# Altium schematic sheet Buttons.SchDoc(Buttons)
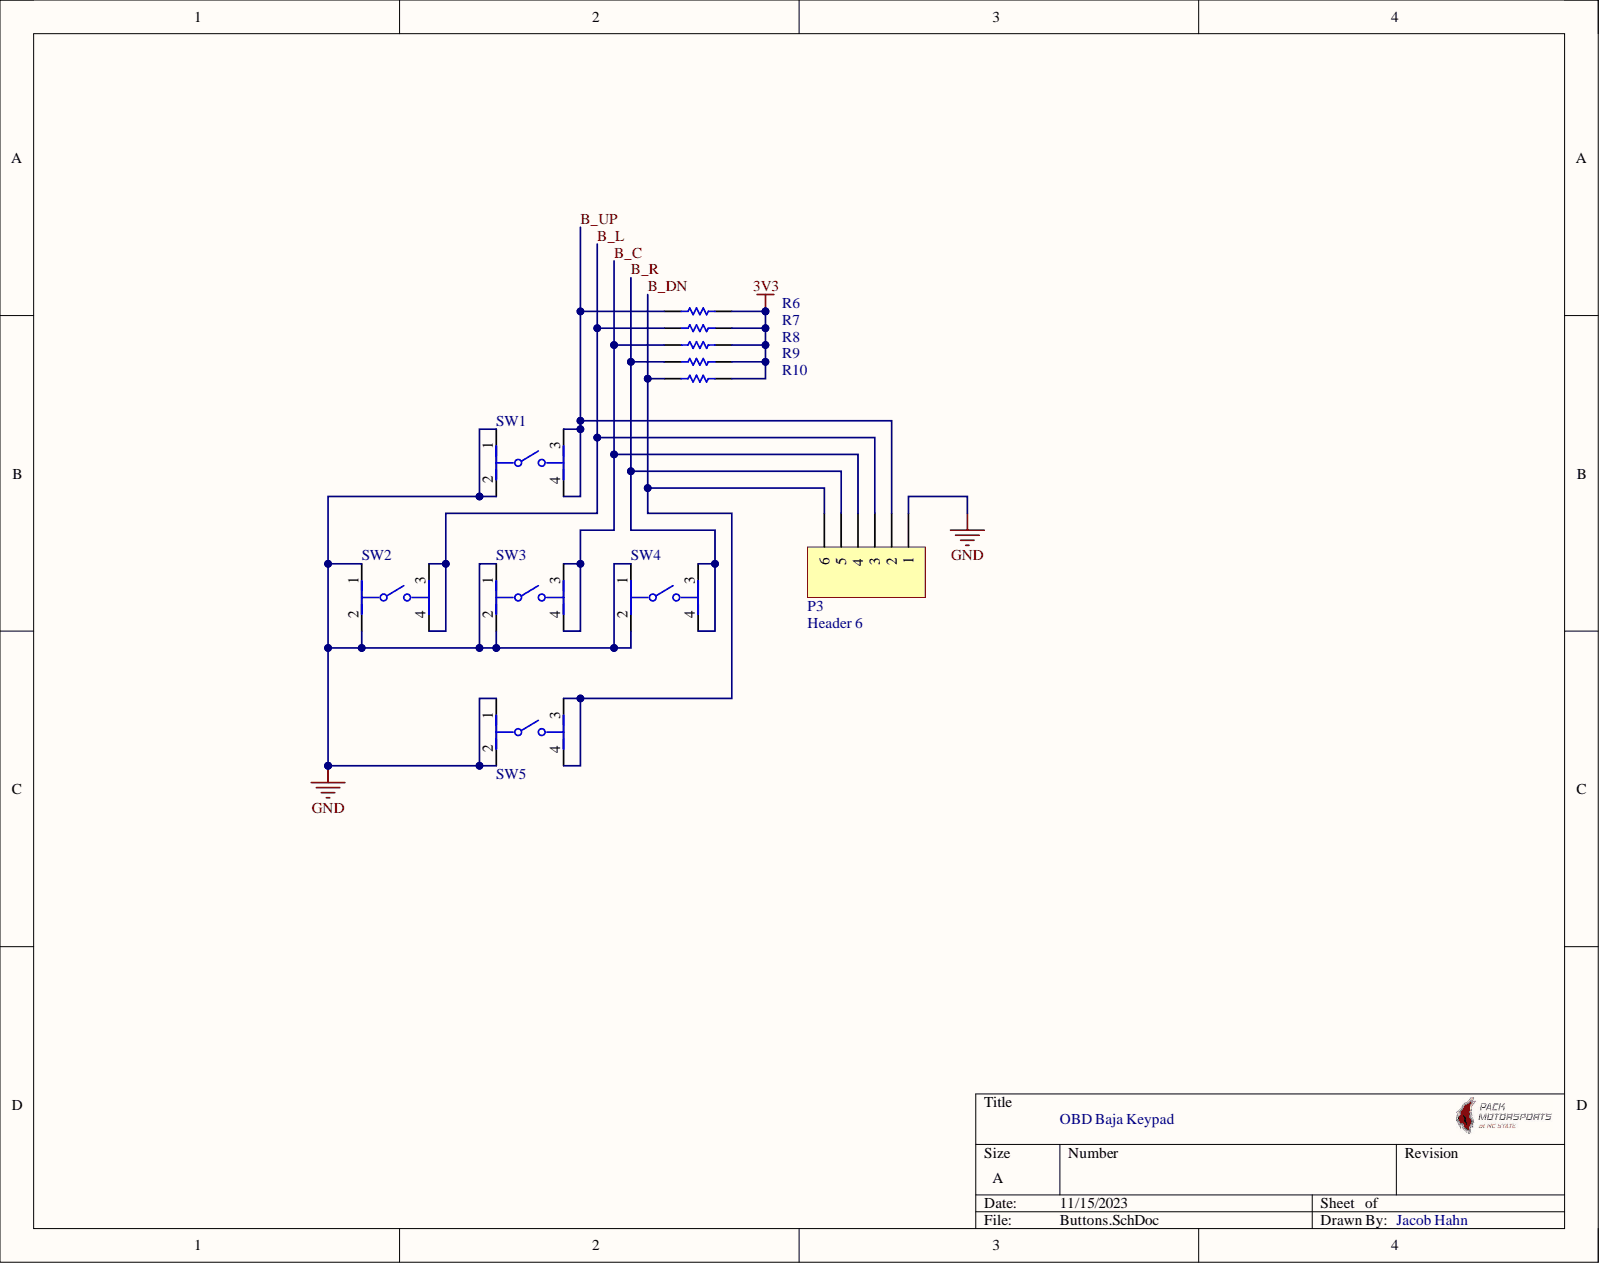
[diagram p0: Buttons.SchDoc]

## Parts
P3  Header 6
R6  RC0805JR-0710KL  (value not drawn)
R7  RC0805JR-0710KL  (value not drawn)
R8  RC0805JR-0710KL  (value not drawn)
R9  RC0805JR-0710KL  (value not drawn)
R10  RC0805JR-0710KL  (value not drawn)
SW1  KMR631NG ULC LFS  (value not drawn)
SW2  KMR631NG ULC LFS  (value not drawn)
SW3  KMR631NG ULC LFS  (value not drawn)
SW4  KMR631NG ULC LFS  (value not drawn)
SW5  KMR631NG ULC LFS  (value not drawn)

## Nets
3V3: R6.2 R7.2 R8.2 R9.2 R10.2
GND: P3.1 SW1.1 SW1.2 SW2.1 SW2.2 SW3.1 SW3.2 SW4.1 SW4.2 SW5.1 SW5.2
B_C: P3.4 R8.1 SW3.3 SW3.4
B_DN: P3.6 R10.1 SW5.3 SW5.4
B_L: P3.3 R7.1 SW2.3 SW2.4
B_R: P3.5 R9.1 SW4.3 SW4.4
B_UP: P3.2 R6.1 SW1.3 SW1.4

## Library data of the parts
P3 = Header 6: Header, 6-Pin; Component Kind: Standard; LatestRevisionDate: 17-Jul-2002; LatestRevisionNote: Re-released for DXP Platform.; Library Name: Miscellaneous Connectors.IntLib; Publisher: Altium Limited
R6-R10 = CMP-2000-05025-1: Chip Resistor, 10 KOhm, +/- 5%, 0.125 W, -55 to 155 degC, 0805 (2012 Metric), RoHS, Tape and Reel; Manufacturer: Yageo; Power Rating: 250mW; Product Range: RCSeries; Resistance: 10kohm; Resistor Case Style: 0805[2012Metric]; Resistor Element Type: ThickFilm; SVHC: ToBeAdvised; Temperature Coefficient: ±100ppm/degC; Voltage Rating: 150V; Automotive Qualification Standard: -; Component Kind: Standard; Datasheet URL: http://www.yageo.ru/pdf/RC0805.pdf; Datasheet Version: REV. 2, 09/2004; Library Name: Altium Content Vault; Manufacturer URL: http://www.yageo.com/; MSL: MSL1-Unlimited; Package Description: 2-Pin Surface Mounted Device, Body 2 x 1.25 mm; Package Reference: 805; Packaging: CutTape; Resistance Tolerance: ±5%
SW1-SW5 = CMP-10040-000019-1: SWITCH TACTILE SPST-NO 50MA 32V; Actuator Type: Standard; Illumination Type: Non-Illuminated; Mechanical Life: 150000Cycles; Operating Force: 300gf; Ratings: IP67; Switch Function: Off-Mom; Throw Configuration: SPST; Component Kind: Standard; Library Name: Altium Content Vault; Max Operating Temperature: 85°C; Min Operating Temperature: -40°C; Mount: Surface Mount; Packaging: Tape and Reel; Termination: Gull Wing
